# Altium schematic sheet HYPNOSIA_controller_V1bis.SchDot(HYPNOSIA_controller_V1bis)
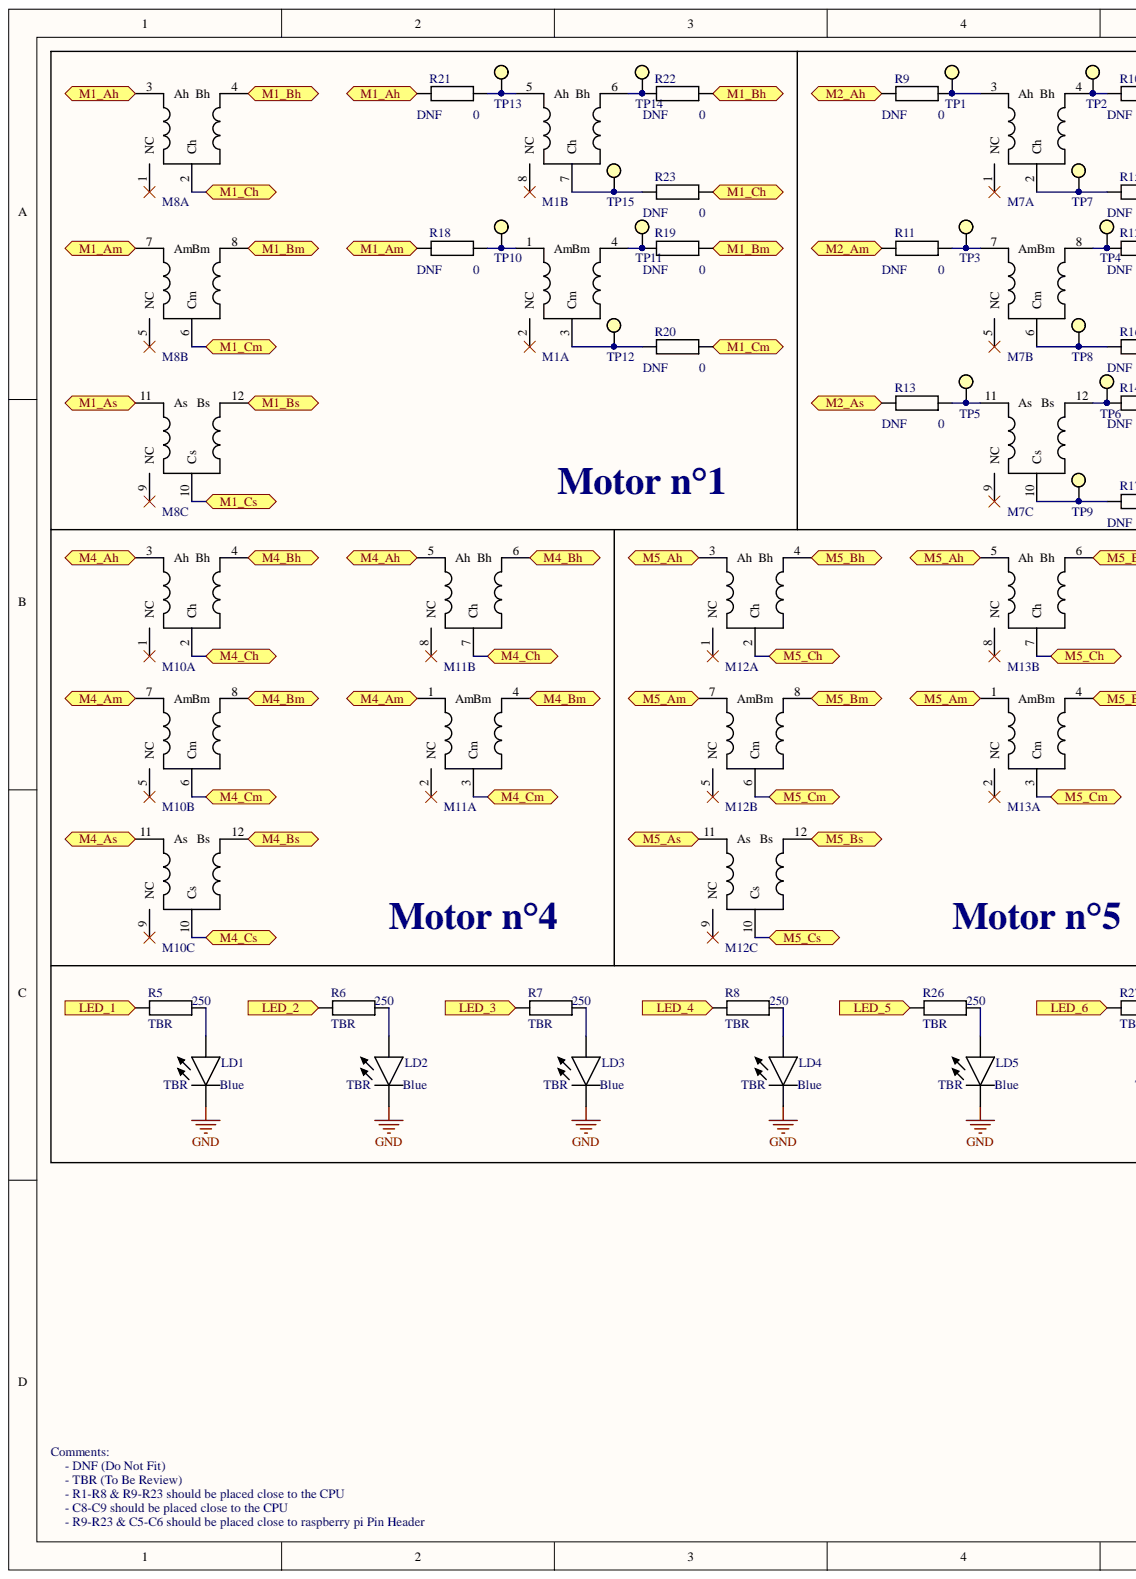
[diagram p0: HYPNOSIA_controller_V1bis.SchDot(HYPNOSIA_controller_V1bis), left]
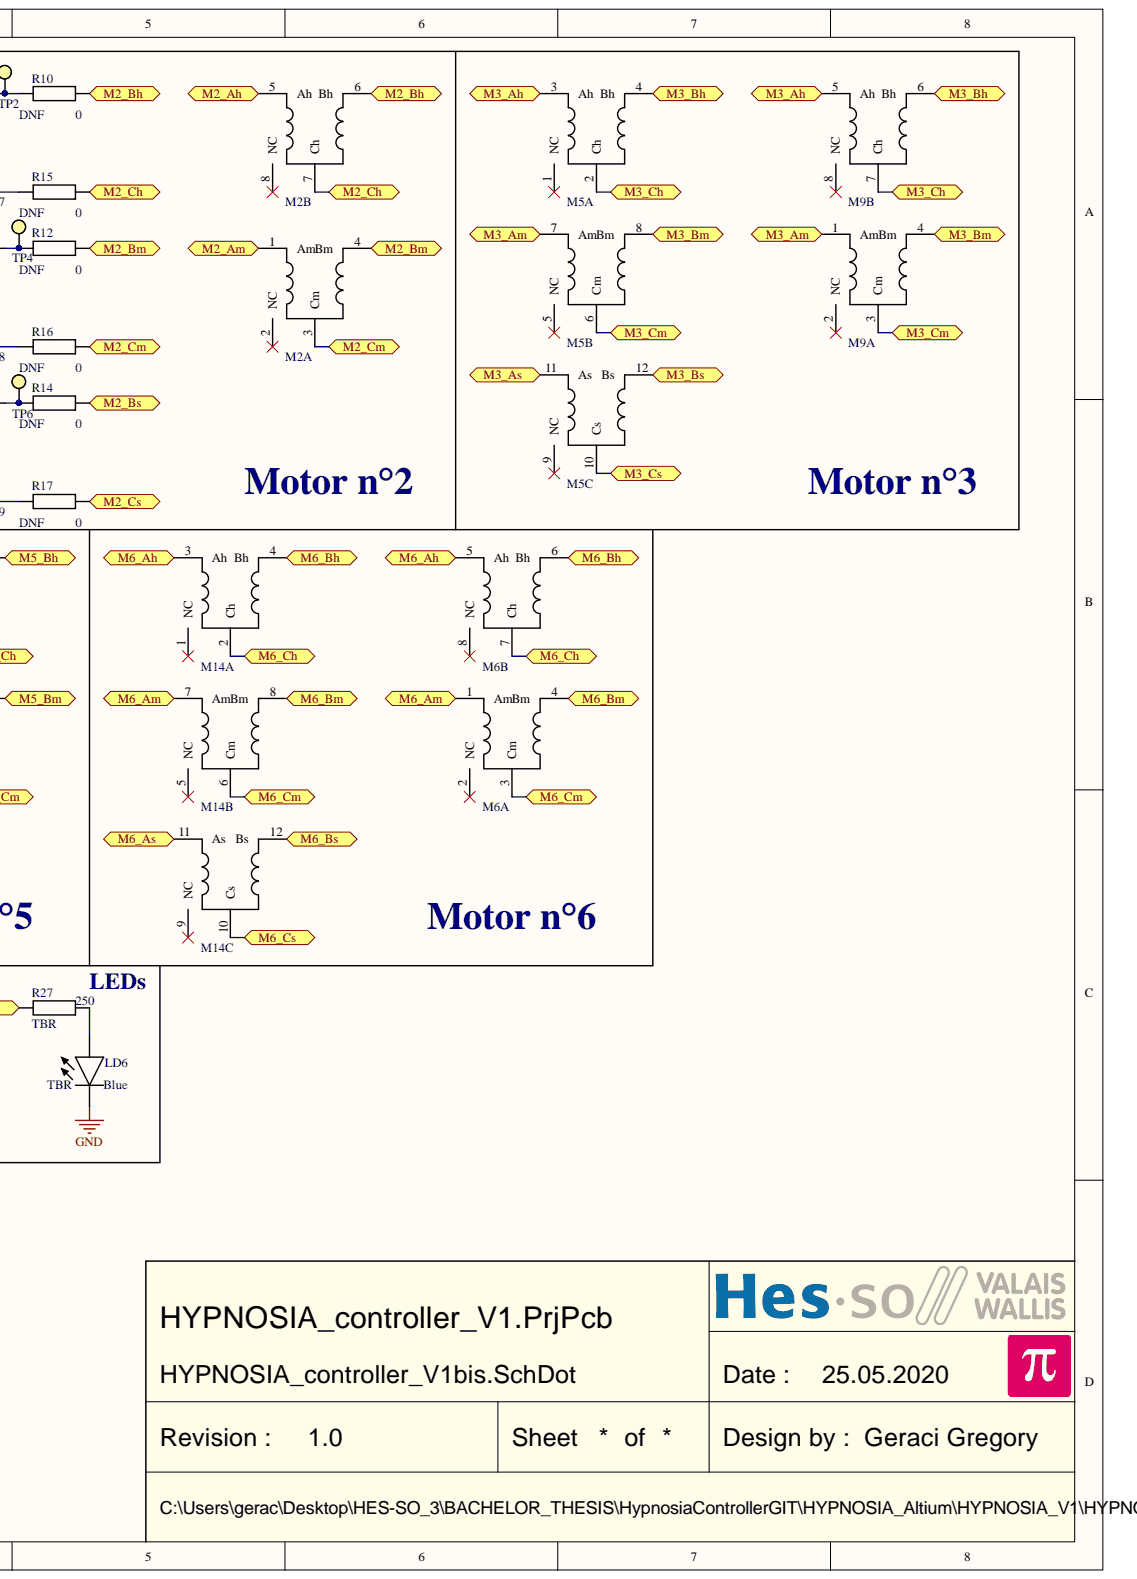
[diagram p1: HYPNOSIA_controller_V1bis.SchDot(HYPNOSIA_controller_V1bis), right]

## Parts
LD1  TBR  value Blue  [LED 2012 REFLOW]
LD2  TBR  value Blue  [LED 2012 REFLOW]
LD3  TBR  value Blue  [LED 2012 REFLOW]
LD4  TBR  value Blue  [LED 2012 REFLOW]
LD5  TBR  value Blue  [LED 2012 REFLOW]
LD6  TBR  value Blue  [LED 2012 REFLOW]
M1  GB3MV2.2  [GB2MV2.1]  (value not drawn)  (parts A,B)
M2  GB3MV2.2  [GB2MV2.1]  (value not drawn)  (parts A,B)
M5  GB3MV2.2  [GB3MV2.2]  (value not drawn)  (parts A,B,C)
M6  GB3MV2.2  [GB2MV2.1]  (value not drawn)  (parts A,B)
M7  GB3MV2.2  [GB3MV2.2]  (value not drawn)  (parts A,B,C)
M8  GB3MV2.2  [GB3MV2.2]  (value not drawn)  (parts A,B,C)
M9  GB3MV2.2  [GB2MV2.1]  (value not drawn)  (parts A,B)
M10  GB3MV2.2  [GB3MV2.2]  (value not drawn)  (parts A,B,C)
M11  GB3MV2.2  [GB2MV2.1]  (value not drawn)  (parts A,B)
M12  GB3MV2.2  [GB3MV2.2]  (value not drawn)  (parts A,B,C)
M13  GB3MV2.2  [GB2MV2.1]  (value not drawn)  (parts A,B)
M14  GB3MV2.2  [GB3MV2.2]  (value not drawn)  (parts A,B,C)
R5  TBR  value 250  [RESISTOR 0603 REFLOW]
R6  TBR  value 250  [RESISTOR 0603 REFLOW]
R7  TBR  value 250  [RESISTOR 0603 REFLOW]
R8  TBR  value 250  [RESISTOR 0603 REFLOW]
R9  DNF  [RESISTOR 0603 REFLOW]
R10  DNF  value 0  [RESISTOR 0603 REFLOW]
R11  DNF  value 0  [RESISTOR 0603 REFLOW]
R12  DNF  value 0  [RESISTOR 0603 REFLOW]
R13  DNF  value 0  [RESISTOR 0603 REFLOW]
R14  DNF  value 0  [RESISTOR 0603 REFLOW]
R15  DNF  value 0  [RESISTOR 0603 REFLOW]
R16  DNF  value 0  [RESISTOR 0603 REFLOW]
R17  DNF  value 0  [RESISTOR 0603 REFLOW]
R18  DNF  value 0  [RESISTOR 0603 REFLOW]
R19  DNF  value 0  [RESISTOR 0603 REFLOW]
R20  DNF  value 0  [RESISTOR 0603 REFLOW]
R21  DNF  value 0  [RESISTOR 0603 REFLOW]
R22  DNF  value 0  [RESISTOR 0603 REFLOW]
R23  DNF  value 0  [RESISTOR 0603 REFLOW]
R26  TBR  value 250  [RESISTOR 0603 REFLOW]
R27  TBR  value 250  [RESISTOR 0603 REFLOW]
TP1  (no value)  [Test Point ARWIN S1751-46R]
TP2  (no value)  [Test Point ARWIN S1751-46R]
TP3  (no value)  [Test Point ARWIN S1751-46R]
TP4  (no value)  [Test Point ARWIN S1751-46R]
TP5  (no value)  [Test Point ARWIN S1751-46R]
TP6  (no value)  [Test Point ARWIN S1751-46R]
TP7  (no value)  [Test Point ARWIN S1751-46R]
TP8  (no value)  [Test Point ARWIN S1751-46R]
TP9  (no value)  [Test Point ARWIN S1751-46R]
TP10  (no value)  [Test Point ARWIN S1751-46R]
TP11  (no value)  [Test Point ARWIN S1751-46R]
TP12  (no value)  [Test Point ARWIN S1751-46R]
TP13  (no value)  [Test Point ARWIN S1751-46R]
TP14  (no value)  [Test Point ARWIN S1751-46R]
TP15  (no value)  [Test Point ARWIN S1751-46R]

## Ports
LED_1, LED_2, LED_3, LED_4, LED_5, LED_6, M1_Ah, M1_Am, M1_As, M1_Bh, M1_Bm, M1_Bs, M1_Ch, M1_Cm, M1_Cs, M2_Ah, M2_Am, M2_As, M2_Bh, M2_Bm, M2_Bs, M2_Ch, M2_Cm, M2_Cs, M3_Ah, M3_Am, M3_As, M3_Bh, M3_Bm, M3_Bs, M3_Ch, M3_Cm, M3_Cs, M4_Ah, M4_Am, M4_As, M4_Bh, M4_Bm, M4_Bs, M4_Ch, M4_Cm, M4_Cs, M5_Ah, M5_Am, M5_As, M5_Bh, M5_Bm, M5_Bs, M5_Ch, M5_Cm, M5_Cs, M6_Ah, M6_Am, M6_As, M6_Bh, M6_Bm, M6_Bs, M6_Ch, M6_Cm, M6_Cs

## Nets
GND: LD1.1 LD2.1 LD3.1 LD4.1 LD5.1 LD6.1
LED_1: R5.2 ; port LED_1
LED_2: R6.2 ; port LED_2
LED_3: R7.2 ; port LED_3
LED_4: R8.2 ; port LED_4
LED_5: R26.2 ; port LED_5
LED_6: R27.2 ; port LED_6
M1_Ah: M8.3(Ah) R21.2 ; port M1_Ah
M1_Am: M8.7(Am) R18.2 ; port M1_Am
M1_As: M8.11(As) ; port M1_As
M1_Bh: M8.4(Bh) R22.1 ; port M1_Bh
M1_Bm: M8.8(Bm) R19.1 ; port M1_Bm
M1_Bs: M8.12(Bs) ; port M1_Bs
M1_Ch: M8.2(Ch) R23.1 ; port M1_Ch
M1_Cm: M8.6(Cm) R20.1 ; port M1_Cm
M1_Cs: M8.10(Cs) ; port M1_Cs
M2_Ah: M2.5(Ah) R9.2 ; port M2_Ah
M2_Am: M2.1(Am) R11.2 ; port M2_Am
M2_As: R13.2 ; port M2_As
M2_Bh: M2.6(Bh) R10.1 ; port M2_Bh
M2_Bm: M2.4(Bm) R12.1 ; port M2_Bm
M2_Bs: R14.1 ; port M2_Bs
M2_Ch: M2.7(Ch) R15.1 ; port M2_Ch
M2_Cm: M2.3(Cm) R16.1 ; port M2_Cm
M2_Cs: R17.1 ; port M2_Cs
M3_Ah: M5.3(Ah) M9.5(Ah) ; port M3_Ah
M3_Am: M5.7(Am) M9.1(Am) ; port M3_Am
M3_As: M5.11(As) ; port M3_As
M3_Bh: M5.4(Bh) M9.6(Bh) ; port M3_Bh
M3_Bm: M5.8(Bm) M9.4(Bm) ; port M3_Bm
M3_Bs: M5.12(Bs) ; port M3_Bs
M3_Ch: M5.2(Ch) M9.7(Ch) ; port M3_Ch
M3_Cm: M5.6(Cm) M9.3(Cm) ; port M3_Cm
M3_Cs: M5.10(Cs) ; port M3_Cs
M4_Ah: M10.3(Ah) M11.5(Ah) ; port M4_Ah
M4_Am: M10.7(Am) M11.1(Am) ; port M4_Am
M4_As: M10.11(As) ; port M4_As
M4_Bh: M10.4(Bh) M11.6(Bh) ; port M4_Bh
M4_Bm: M10.8(Bm) M11.4(Bm) ; port M4_Bm
M4_Bs: M10.12(Bs) ; port M4_Bs
M4_Ch: M10.2(Ch) M11.7(Ch) ; port M4_Ch
M4_Cm: M10.6(Cm) M11.3(Cm) ; port M4_Cm
M4_Cs: M10.10(Cs) ; port M4_Cs
M5_Ah: M12.3(Ah) M13.5(Ah) ; port M5_Ah
M5_Am: M12.7(Am) M13.1(Am) ; port M5_Am
M5_As: M12.11(As) ; port M5_As
M5_Bh: M12.4(Bh) M13.6(Bh) ; port M5_Bh
M5_Bm: M12.8(Bm) M13.4(Bm) ; port M5_Bm
M5_Bs: M12.12(Bs) ; port M5_Bs
M5_Ch: M12.2(Ch) M13.7(Ch) ; port M5_Ch
M5_Cm: M12.6(Cm) M13.3(Cm) ; port M5_Cm
M5_Cs: M12.10(Cs) ; port M5_Cs
M6_Ah: M6.5(Ah) M14.3(Ah) ; port M6_Ah
M6_Am: M6.1(Am) M14.7(Am) ; port M6_Am
M6_As: M14.11(As) ; port M6_As
M6_Bh: M6.6(Bh) M14.4(Bh) ; port M6_Bh
M6_Bm: M6.4(Bm) M14.8(Bm) ; port M6_Bm
M6_Bs: M14.12(Bs) ; port M6_Bs
M6_Ch: M6.7(Ch) M14.2(Ch) ; port M6_Ch
M6_Cm: M6.3(Cm) M14.6(Cm) ; port M6_Cm
M6_Cs: M14.10(Cs) ; port M6_Cs
NetLD1_2: LD1.2 R5.1
NetLD2_2: LD2.2 R6.1
NetLD3_2: LD3.2 R7.1
NetLD4_2: LD4.2 R8.1
NetLD5_2: LD5.2 R26.1
NetLD6_2: LD6.2 R27.1
NetM1_1: M1.1(Am) R18.1 TP10.1
NetM1_3: M1.3(Cm) R20.2 TP12.1
NetM1_4: M1.4(Bm) R19.2 TP11.1
NetM1_5: M1.5(Ah) R21.1 TP13.1
NetM1_6: M1.6(Bh) R22.2 TP14.1
NetM1_7: M1.7(Ch) R23.2 TP15.1
NetM7_2: M7.2(Ch) R15.2 TP7.1
NetM7_3: M7.3(Ah) R9.1 TP1.1
NetM7_4: M7.4(Bh) R10.2 TP2.1
NetM7_6: M7.6(Cm) R16.2 TP8.1
NetM7_7: M7.7(Am) R11.1 TP3.1
NetM7_8: M7.8(Bm) R12.2 TP4.1
NetM7_10: M7.10(Cs) R17.2 TP9.1
NetM7_11: M7.11(As) R13.1 TP5.1
NetM7_12: M7.12(Bs) R14.2 TP6.1

Not connected: M1 2(NC) 8(NC); M2 2(NC) 8(NC); M5 1(NC) 5(NC) 9(NC); M6 2(NC) 8(NC); M7 1(NC) 5(NC) 9(NC); M8 1(NC) 5(NC) 9(NC); M9 2(NC) 8(NC); M10 1(NC) 5(NC) 9(NC); M11 2(NC) 8(NC); M12 1(NC) 5(NC) 9(NC); M13 2(NC) 8(NC); M14 1(NC) 5(NC) 9(NC)

## Library data of the parts
LD1-LD6 = LED: LED generic; Library Name: Diode.IntLib; Component Kind: Standard
M1 M2 M6 M9 M11 M13 = GB2MV2.1: Soprod Gear Box, 2 motors 102.02.xxx; Value: GB3MV2.2; Library Name: Motor.IntLib; Component Kind: Standard
M5 M7 M8 M10 M12 M14 = GB3MV2.2: Soprod Gear Box, 3 motors 103.02.xxx; Value: GB3MV2.2; Library Name: Motor.IntLib; Component Kind: Standard
R5-R8 R10-R23 R26 R27 = Resistor: Library Name: Resistor.IntLib; Description: Resistor; Component Kind: Standard
R9 = Resistor: Value: 0; Library Name: Resistor.IntLib; Description: Resistor; Component Kind: Standard
TP1-TP15 = Test Point: Library Name: Testpoint.IntLib; Description: Test Point; Component Kind: Standard
